# Altium schematic sheet S1.SchDoc(S1)
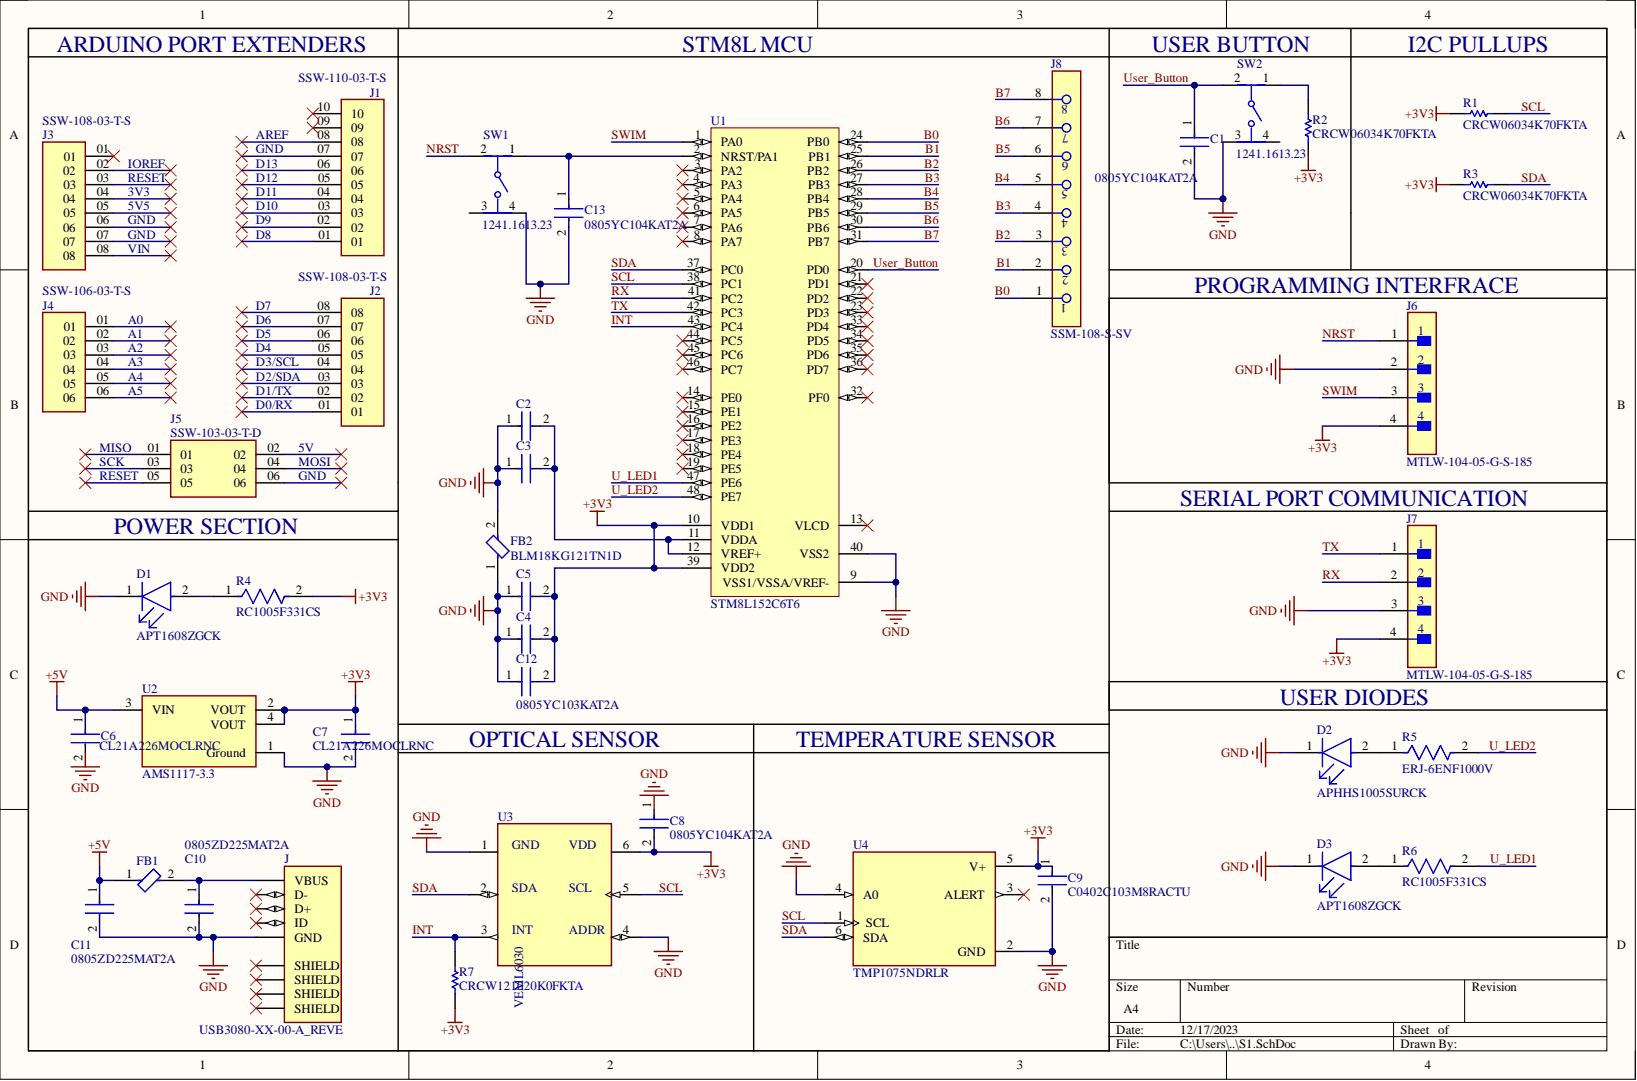
[diagram p0: S1.SchDoc]

## Parts
C1  0805YC104KAT2A  [FP-0805-L_2_01_0_2-W_1_25-MFG]
C2  0805YC104KAT2A  [FP-0805-L_2_01_0_2-W_1_25-MFG]
C3  0805YC104KAT2A  [FP-0805-L_2_01_0_2-W_1_25-MFG]
C4  0805YC104KAT2A  [FP-0805-L_2_01_0_2-W_1_25-MFG]
C5  0805YC104KAT2A  [FP-0805-L_2_01_0_2-W_1_25-MFG]
C6  CL21A226MOCLRNC  [FP-CL21-IPC_C]
C7  CL21A226MOCLRNC  [FP-CL21-IPC_C]
C8  0805YC104KAT2A  [FP-0805-L_2_01_0_2-W_1_25-MFG]
C9  C0402C103M8RACTU  [FP-C0402-BB-MFG]
C10  0805ZD225MAT2A  [FP-0805-L_2_01_0_2-W_1_25-IPC_B]
C11  0805ZD225MAT2A  [FP-0805-L_2_01_0_2-W_1_25-IPC_B]
C12  0805YC103KAT2A  [FP-0805-L_2_01_0_2-W_1_25-IPC_C]
C13  0805YC104KAT2A  [FP-0805-L_2_01_0_2-W_1_25-MFG]
D1  APT1608ZGCK  [FP-APT1608ZGCK-MFG]
D2  APHHS1005SURCK  [FP-APHHS1005SURCK-MFG]
D3  APT1608ZGCK  [FP-APT1608ZGCK-MFG]
FB1  BLM18KG121TN1D  [FP-BLM18-0_15-t0_6-d1_2-IPC_A]
FB2  BLM18KG121TN1D  [FP-BLM18-0_15-t0_6-d1_2-IPC_A]
J  USB3080-XX-00-A_REVE  [GCT_USB3080-XX-00-A_REVE]
J1  SSW-110-03-T-S  [SAMTEC_SSW-110-03-T-S]
J2  SSW-108-03-T-S  [SAMTEC_SSW-108-XX-X-S]
J3  SSW-108-03-T-S  [SAMTEC_SSW-108-XX-X-S]
J4  SSW-106-03-T-S  [SAMTEC_SSW-106-03-T-S]
J5  SSW-103-03-T-D  [SAMTEC_SSW-103-03-T-D]
J6  MTLW-104-05-G-S-185  [FP-MTLW-104-05-G-S-185-MFG]
J7  MTLW-104-05-G-S-185  [FP-MTLW-104-05-G-S-185-MFG]
J8  SSM-108-S-SV  [FP-SSM-108-S-SV-MFG]
R1  CRCW06034K70FKTA  [RESC1609X50X30NL10T20]
R2  CRCW06034K70FKTA  [RESC1609X50X30NL10T20]
R3  CRCW06034K70FKTA  [RESC1609X50X30NL10T20]
R4  RC1005F331CS  [FP-RC1005-IPC_B]
R5  ERJ-6ENF1000V  [FP-ERJ6EN-MFG]
R6  RC1005F331CS  [FP-RC1005-IPC_B]
R7  CRCW121020K0FKTA  [RESC3225X60X45LL20T20]
SW1  1241.1613.23  [FP-1241_1613_23-MFG]
SW2  1241.1613.23  [FP-1241_1613_23-MFG]
U1  STM8L152C6T6  [LQFP48_M]
U2  AMS1117-3.3  [FP-AMS1117-IPC_C]
U3  VEML6030  [VISHAY_VEML6030_2X2X0.87]
U4  TMP1075NDRLR  [SOT50P160X60-6N]

## Nets
+3V3: C4.2 C5.2 C7.1 C8.2 C9.1 C12.2 J6.4 J7.4 R1.1 R2.1 R3.1 R4.2 R7.1 U1.10(VDD1) U1.39(VDD2) U2.2(VOUT) U2.4(VOUT) U3.6 U4.5(V+)
+5V: C6.1 C11.1 FB1.1 U2.3(VIN)
GND: C1.2 C2.1 C3.1 C4.1 C5.1 C6.2 C7.2 C8.1 C9.2 C10.2 C11.2 C12.1 C13.2 D1.1 D2.1 D3.1 FB2.1 FB2.2 J.5(GND) J6.2 J7.3 SW1.4 SW2.3 U1.9(VSS1/VSSA/VREF-) U1.40(VSS2) U2.1(Ground) U3.1 U3.4 U4.2(GND) U4.4(A0)
B0: J8.1 U1.24(PB0)
B1: J8.2 U1.25(PB1)
B2: J8.3 U1.26(PB2)
B3: J8.4 U1.27(PB3)
B4: J8.5 U1.28(PB4)
B5: J8.6 U1.29(PB5)
B6: J8.7 U1.30(PB6)
B7: J8.8 U1.31(PB7)
INT: R7.2 U1.43(PC4) U3.3
NRST: J6.1 SW1.2
RX: J7.2 U1.41(PC2)
SCL: R1.2 U1.38(PC1) U3.5 U4.1(SCL)
SDA: R3.2 U1.37(PC0) U3.2 U4.6(SDA)
SWIM: J6.3 U1.1(PA0)
TX: J7.1 U1.42(PC3)
U_LED1: R6.2 U1.47(PE6)
U_LED2: R5.2 U1.48(PE7)
User_Button: C1.1 SW2.2 U1.20(PD0)
NetC2_2: C2.2 C3.2 U1.11(VDDA) U1.12(VREF+)
NetC10_1: C10.1 FB1.2 J.1(VBUS)
NetC13_1: C13.1 SW1.1 U1.2(NRST/PA1)
NetD1_2: D1.2 R4.1
NetD2_2: D2.2 R5.1
NetD3_2: D3.2 R6.1
NetR2_2: R2.2 SW2.1

Not connected: J 2(D-) 3(D+) 4(ID) 6(SHIELD) 7(SHIELD) 8(SHIELD) 9(SHIELD); J1 01 02 03 04 05 06 07 08 09 10; J2 01 02 03 04 05 06 07 08; J3 01 02 03 04 05 06 07 08; J4 01 02 03 04 05 06; J5 01 02 03 04 05 06; SW1 3; SW2 4; U1 3(PA2) 4(PA3) 5(PA4) 6(PA5) 7(PA6) 8(PA7) 13(VLCD) 14(PE0) 15(PE1) 16(PE2) 17(PE3) 18(PE4) 19(PE5) 21(PD1) 22(PD2) 23(PD3) 32(PF0) 33(PD4) 34(PD5) 35(PD6) 36(PD7) 44(PC5) 45(PC6) 46(PC7); U4 3(ALERT)

## Library data of the parts
C1-C5 C8 C13 = CMP-2007-04454-2: General Purpose Ceramic Capacitor, 0805, 100nF, 10%, X7R, 0.15, 16V; Dissipation Factor: 12.5%; Insulation Resistance: 100GR; Capacitance: 100nF; Case Code (Imperial): 0805; Case Code (Metric): 2012; Case/Package: 0805; Component Kind: Standard; Construction: Flat; Depth: 1.25mm; Dielectric: X7R; Lead Free: Lead Free; Length: 2.01mm; Library Name: Altium Content Vault; Max Operating Temperature: 125°C; Min Operating Temperature: -55°C; Mount: Surface Mount; Number of Pins: 2; Package Quantity: 1; Packaging: Tape and Reel; Radiation Hardening: No; REACH SVHC: No SVHC; RoHS Compliant: Yes; Series: -; Termination: SMD/SMT; Thickness: 0.037inch; Tolerance: 10%; Voltage Rating: 16V; Voltage Rating (DC): 16V; Width: 0.049inch
C6 C7 = CMP-13271-002990-1: CL21 Series 0805 22uF 16V ±20% Tolerance X5R Multilayer Ceramic Chip Capacitor; Capacitance: 22uF; Case Code (Imperial): 0805; Case Code (Metric): 2012; Case/Package: 0805; Component Kind: Standard; Length: 0.079inch; Library Name: Altium Content Vault; Max Operating Temperature: 85°C; Min Operating Temperature: -55°C; Mount: Surface Mount; Packaging: Tape and Reel; Thickness: 0.037inch; Tolerance: 20%; Voltage Rating: 16V; Width: 0.049inch
C9 = CMP-2008-03079-2: CAP CER 10000PF 10V X7R 0402; Manufacturer: Kemet; Capacitance: 10,000 pF; Material: Ceramic; Temperature Coefficient: 15%; Tolerance: 0.2; Value: 10,000 pF; Automotive: No; Category: Cap; Component Kind: Standard; ComponentLink2Description: Datasheet; ComponentLink2URL: https://content.kemet.com/datasheets/KEM_C1002_X7R_SMD.pdf; Device Class L1: Passive Components; Device Class L2: Capacitors; Device Class L3: Ceramic Capacitors; Digikey Description: CAP CER 10000PF 10V X7R 0402; Height: 0.55mm; Ipc Land Pattern Name: CAPC10050X50; Lead Free: Yes; Library Name: Altium Content Vault; Manufacturer Part Number: C0402C103M8RACTU; Mouser Description: Multilayer Ceramic Capacitors MLCC - SMD/SMT 10volts 10000pF X7R 20%; Octopart: https://octopart.com/c0402c103m8ractu-kemet-12595671; Package: C0402-BB; RoHS: TRUE; Temperature: -55°C to +125°C; Temperature Characteristic: X7R; Temperature Range High: +125°C; Temperature Range Low: -55°C; Voltage Rating: 10 V
C10 C11 = CMP-2007-04684-2: General Purpose Ceramic Capacitor, 0805, 2.2uF, 20%, X5R, 15%, 10V; Dissipation Factor: 5%; Capacitance: 2.2uF; Case Code (Imperial): 0805; Case Code (Metric): 2012; Case/Package: 0805; Component Kind: Standard; Construction: Flat; Depth: 1.25mm; Dielectric: X5R; Height: 1.4mm; Lead Free: Lead Free; Length: 2.01mm; Library Name: Altium Content Vault; Max Operating Temperature: 85°C; Min Operating Temperature: -55°C; Mount: Surface Mount; Number of Pins: 2; Package Quantity: 1; Packaging: Tape and Reel; Radiation Hardening: No; REACH SVHC: No SVHC; RoHS Compliant: Yes; Series: -; Termination: SMD/SMT; Thickness: 0.055inch; Tolerance: 20%; Voltage Rating: 10V; Voltage Rating (DC): 10V; Width: 0.049inch
C12 = CMP-2007-04708-2: General Purpose Ceramic Capacitor, 0805, 10nF, 10%, X7R, 0.15, 16V; Dissipation Factor: 3.5%; Capacitance: 10nF; Case Code (Imperial): 0805; Case Code (Metric): 2012; Case/Package: 0805; Component Kind: Standard; Construction: Flat; Depth: 1.25mm; Dielectric: X7R; Dielectric Material: Ceramic; Height: 0.94mm; Lead Free: Lead Free; Length: 2.01mm; Library Name: Altium Content Vault; Max Operating Temperature: 125°C; Min Operating Temperature: -55°C; Mount: Surface Mount; Number of Pins: 2; Package Quantity: 4000; Packaging: Tape and Reel; Radiation Hardening: No; REACH SVHC: No SVHC; RoHS Compliant: Yes; Termination: SMD/SMT; Thickness: 0.037inch; Tolerance: 10%; Voltage Rating: 16V; Voltage Rating (DC): 16V; Width: 0.049inch
D1 D3 = CMP-44529-000024-1: LED GREEN CLEAR CHIP SMD; Dominant Wavelength: 525nm; Forward Current: 20mA; Forward Voltage: 3.3V; Lens Color: Colorless; Luminous Intensity: 350mcd; Viewing Angle: 120°; Case Code (Imperial): 0603; Case Code (Metric): 1608; Case/Package: 0603; Color: Green; Component Kind: Standard; Height: 0.75mm; Illumination Color: Green; Lead Free: Lead Free; Length: 1.6mm; Lens Transparency: Clear; Library Name: Altium Content Vault; Max Operating Temperature: 85°C; Min Operating Temperature: -40°C; Mount: Surface Mount; Package Quantity: 1; Packaging: Tape and Reel; Test Current: 20mA; Width: 0.8mm
D2 = CMP-2000-05787-2: LED RED CLEAR CHIP SMD; Dominant Wavelength: 630nm; Forward Current: 20mA; Forward Voltage: 1.95V; Luminous Intensity: 220mcd; Number of LEDs: 1; Viewing Angle: 120°; Wavelength: 635nm; Case Code (Imperial): 0402; Case Code (Metric): 1005; Case/Package: 0402; Color: Red; Component Kind: Standard; Height: 0.6mm; Illumination Color: Red; Lead Free: Lead Free; Length: 1mm; Lens Color: Clear; Lens Style: Clear; Library Name: Altium Content Vault; Max Operating Temperature: 85°C; Min Operating Temperature: -40°C; Mount: Surface Mount; Number of Pins: 2; Package Quantity: 2000; Packaging: Tape and Reel; Test Current: 20mA; Width: 0.5mm
FB1 FB2 = CMP-0686-00554-8: FERRITE BEAD 120 OHM 0603 1LN; Current Rating: 3A; DC Resistance (DCR): 30mR; Impedance: 120R; Number of Circuits: 1; Test Frequency: 100MHz; Tolerance: 25%; Case Code (Imperial): 0603; Case Code (Metric): 1608; Case/Package: 0603; Component Kind: Standard; Core Material: Ferrite; Depth: 0.95mm; Height: 0.8mm; Inductor Type: FERRITE BEAD; Lead Free: Lead Free; Length: 1.6mm; Library Name: Altium Content Vault; Material: Ferrite; Max Current Rating: 3A; Max DC Current: 3A; Max Operating Temperature: 125°C; Min Operating Temperature: -55°C; Number of Lines: 1; Number of Pins: 2; Package Quantity: 4000; Packaging: Tape and Reel; REACH SVHC: No SVHC; RoHS Compliant: Yes; Series: BLM18K; Termination: SMD/SMT; Width: 0.8mm
J = USB3080-XX-00-A_REVE: USB - micro B USB 2.0 Receptacle Connector 5 Position Surface Mount, Right Angle; MF: Global Connector Technology; MP: USB3080-30-00-A; Availability: Unavailable; Component Kind: Standard; Library Name: USB3080-XX-00-A_REVE.IntLib; Package: None; Price: None; SnapEDA_Link: https://www.snapeda.com/parts/USB3080-30-00-A/Global%20Connector%20Technology/view-part/697012/?ref=snap
J1 = SSW-110-03-T-S: MF: Samtec; Availability: Unavailable; Component Kind: Standard; Library Name: SSW-110-03-T-S.IntLib; MP: SSW-110-03-T-S; Package: None; Price: None
J2 J3 = SSW-108-XX-X-S: 8 Position Receptacle Connector Through Hole, Right Angle; MF: Samtec; MP: SSW-108-03-F-S; Availability: Bad; Check_prices: https://www.snapeda.com/parts/SSW-108-03-F-S/Samtec/view-part/3433832/?ref=eda; Component Kind: Standard; Library Name: SSW-108-03-T-S.IntLib; Package: None; Price: None; Purchase-URL: https://pricing.snapeda.com/search/part/SSW-108-03-F-S/?ref=eda; SnapEDA_Link: https://www.snapeda.com/parts/SSW-108-03-F-S/Samtec/view-part/3433832/?ref=snap
J4 = SSW-106-03-T-S: MF: Samtec Inc.; Availability: Unavailable; Component Kind: Standard; Library Name: SSW-106-03-T-S.IntLib; MP: SSW-106-03-T-S; Package: None; Price: None
J5 = SSW-103-03-T-D: SSW Series .100 Tiger Buyâ„¢ Socket Strip; MF: Samtec; Availability: In Stock; Check_prices: https://www.snapeda.com/parts/SSW-103-03-T-D/Samtec/view-part/?ref=eda; Component Kind: Standard; Library Name: SSW-103-03-T-D.IntLib; MP: SSW-103-03-T-D; Package: None; Price: None; Purchase-URL: https://pricing.snapeda.com/search/part/SSW-103-03-T-D/?ref=eda; SnapEDA_Link: https://www.snapeda.com/parts/SSW-103-03-T-D/Samtec/view-part/?ref=snap
J6 J7 = CMP-02766-000562-1: CONN HEADER VERT 4POS 2.54MM; Connector Type: Male Pin; Contact Resistance: 15mR; Gender: Male; Number of Contacts: 4; Color: Black; Component Kind: Standard; Contact Material: Brass; Contact Mating Length: 0.185inch; Contact Plating: Gold; Depth: 2.48mm; Height: 6.4mm; Housing Color: Black; Housing Material: Polymer; Length: 29.64mm; Library Name: Altium Content Vault; Max Operating Temperature: 105°C; Min Operating Temperature: -40°C; Mount: Through Hole; Number of Positions: 4; Number of Rows: 1; Orientation: Straight; Packaging: Rail/Tube; Pitch: 2.54mm; Radiation Hardening: No; Termination: Solder
J8 = CMP-02766-001390-1: CONN RCPT 8POS 0.1 GOLD SMD; Connector Type: Receptacle; Contact Resistance: 10mR; Gender: Female; Max Current Rating: 6.9A; Max Voltage Rating (AC): 475V; Number of Contacts: 8; Pitch: 2.54mm; Color: Black; Component Kind: Standard; Contact Material: Bronze; Contact Plating: Gold; Contact Type: Female Socket; Depth: 4.42mm; Housing Color: Black; Housing Material: Polymer; Lead Free: Lead Free; Length: 20.32mm; Library Name: Altium Content Vault; Max Operating Temperature: 125°C; Min Operating Temperature: -55°C; Mount: Surface Mount; Number of Positions: 8; Number of Rows: 1; Orientation: Straight; Packaging: Bulk; Radiation Hardening: No; RoHS Compliant: Yes; Series: SSM; Termination: Solder; Width: 2.54mm
R1-R3 = CMP-2000-03412-1: Manufacturer: Vishay Dale; Component Kind: Standard; Library Name: Altium Content Vault; Part Number: CRCW06034K70FKTA
R4 R6 = CMP-13261-004965-1: Res Thick Film 0402 330Ω 1% 0.063W ±100ppm/°C Molded SMD T/R; Max Power Dissipation: 63mW; Resistance: 330R; Case Code (Imperial): 0402; Case Code (Metric): 1005; Case/Package: 0402; Component Kind: Standard; Composition: Thick Film; Contact Plating: Tin; Depth: 0.5mm; Features: Moisture Resistant; Height: 0.016inch; Length: 0.039inch; Library Name: Altium Content Vault; Max Operating Temperature: 155°C; Military Standard: Not; Min Operating Temperature: -55°C; Number of Terminations: 2; Package Quantity: 1; Packaging: Tape and Reel; Power Rating: 63mW; Radiation Hardening: No; Temperature Coefficient: 100ppm/°C; Tolerance: 1%; Width: 0.02inch
R5 = CMP-2001-04374-6: RES SMD 100 OHM 1% 1/8W 0805; Max Power Dissipation: 125mW; Resistance: 100R; Temperature Coefficient: 100ppm/°C; Voltage Rating: 150V; Case Code (Imperial): 0805; Case Code (Metric): 2012; Case/Package: 0805; Component Kind: Standard; Construction: Rectangular; Contact Plating: Tin; Depth: 1.25mm; Features: Automotive AEC-Q200; Height: 0.6mm; Lead Free: Lead Free; Length: 2mm; Library Name: Altium Content Vault; Max Operating Temperature: 155°C; Military Standard: Not; Min Operating Temperature: -55°C; Mount: Surface Mount; Number of Pins: 2; Number of Terminations: 2; Package Quantity: 1; Packaging: Tape and Reel; Power Rating: 125mW; Radiation Hardening: No; REACH SVHC: No SVHC; Resistance Tolerance: 1%; Resistor Type: Thick Film; RoHS Compliant: Yes; Series: ERJ; Size Code: 0805; Technology: THICK FILM; Termination: SMD/SMT; Tolerance: 1%; Voltage Rating (DC): 150V; Width: 1.2mm
R7 = CMP-1015-00655-2: Manufacturer: Vishay Dale; Component Kind: Standard; Library Name: Altium Content Vault; Part Number: CRCW121020K0FKTA
SW1 SW2 = CMP-26316-000007-1: SWITCH TACTILE SPST-NO 0.05A 42V; Actuator Material: Polyphenylene; Actuator Type: Standard; Current Rating: 50mA; Illumination Type: Non-Illuminated; Max Voltage Rating (AC): 30V; Mechanical Life: 1000000Cycles; Operating Force: 1.8N; Ratings: IP40; Switch Function: Off-Mom; Switch Travel: 0.35mm; Throw Configuration: SPST; Case/Package: SMD/SMT; Component Kind: Standard; Contact Material: Gold; Depth: 11.8mm; Length: 11.4mm; Library Name: Altium Content Vault; Max Current Rating: 50mA; Max Operating Temperature: 85°C; Max Voltage Rating (DC): 42V; Min Operating Temperature: -40°C; Mount: Surface Mount; Orientation: Straight; Package Quantity: 450; Packaging: Tape and Reel; Radiation Hardening: No; RoHS Compliant: Yes; Series: SMS; Termination: J-Lead; Voltage Rating (AC): 30V; Voltage Rating (DC): 42V; Weight: 0.028219oz
U1 = CMP-0235-00048-1: STM8L ARM-based EnergyLite 8-bit MCU, LCD, 32 kB Flash, 2 kB Internal RAM, -40 to +85°C Temperature, 48-pin LQFP, Tray; Component Kind: Standard; ComponentLink1Description: STM8L151/152,x4,x6 Datasheet; ComponentLink1URL: http://www.st.com/internet/com/TECHNICAL_RESOURCES/TECHNICAL_LITERATURE/DATASHEET/CD00240181.pdf; ComponentLink2Description: STM8L Family Web Page; ComponentLink2URL: http://www.st.com/internet/mcu/subclass/1381.jsp; ComponentLink3Description: st.com Home; ComponentLink3URL: http://www.st.com/internet/com/home/home.jsp; Library Name: Altium Content Vault; PackageDescription: 48-Pin Low-Profile Quad Flat Pack, 7 x 7 mm Body, 0.5 mm Pitch (LQFP48); PackageReference: LQFP48; PackageVersion: rev13, Apr-2011
U2 = CMP-209535-000001-1: LDO Voltage Regulators 1A, 3.3V; Manufacturer: Advanced Monolithic Systems; Dropout Voltage: 1.1V; Max Junction Temp: +125°C; Max Power Dissipation: 1.2W; Max Supply Voltage: 15V; Nominal Supply Current: 5mA; Number Of Outputs: 1; Output Type: Fixed; Standoff Height: 0.64mm; Automotive: No; Category: IC; Component Kind: Standard; ComponentLink2Description: Datasheet; ComponentLink2URL: http://www.advanced-monolithic.com/pdf/ds1117.pdf; Device Class L1: Integrated Circuits (ICs); Device Class L2: Power Management ICs; Device Class L3: Voltage Regulators - Linear; Height: 1.8mm; Ipc Land Pattern Name: SOT229P700X180-4; Lead Free: Yes; Library Name: Altium Content Vault; Manufacturer Part Number: AMS1117-3.3; Octopart: https://octopart.com/ams1117-3.3-advanced+monolithic+systems-25899300; Output Current: 1A; Output Voltage: 3.3V; Package: AMS1117; RoHS: TRUE; Temperature: -40°C to +125°C; Temperature Range High: +125°C; Temperature Range Low: -40°C
U3 = VEML6030: Optical Sensor Ambient 550nm IÂ²C 6-SMD, No Lead; MF: Vishay; Availability: In Stock; Check_prices: https://www.snapeda.com/parts/VEML6030/Vishay+Semiconductor+Opto+Division/view-part/?ref=eda; Component Kind: Standard; Library Name: VEML6030.IntLib; MP: VEML6030; Package: SMD-6 Vishay Semiconductor; Price: None; Purchase-URL: https://pricing.snapeda.com/search/part/VEML6030/?ref=eda; SnapEDA_Link: https://www.snapeda.com/parts/VEML6030/Vishay+Semiconductor+Opto+Division/view-part/?ref=snap
U4 = TMP1075NDRLR: 1Â°C IÂ²C temperature sensor with performance upgrades to industry standard LM75 / TMP75; MF: Texas Instruments; Availability: In Stock; Check_prices: https://www.snapeda.com/parts/TMP1075NDRLR/Texas+Instruments/view-part/?ref=eda; Component Kind: Standard; Library Name: TMP1075NDRLR.IntLib; MP: TMP1075NDRLR; Package: None; Price: None; Purchase-URL: https://pricing.snapeda.com/search/part/TMP1075NDRLR/?ref=eda; SnapEDA_Link: https://www.snapeda.com/parts/TMP1075NDRLR/Texas+Instruments/view-part/?ref=snap
